# Altium schematic sheet 09_ATK_RK3588S_OSC_PLL_PMUIO.SchDoc
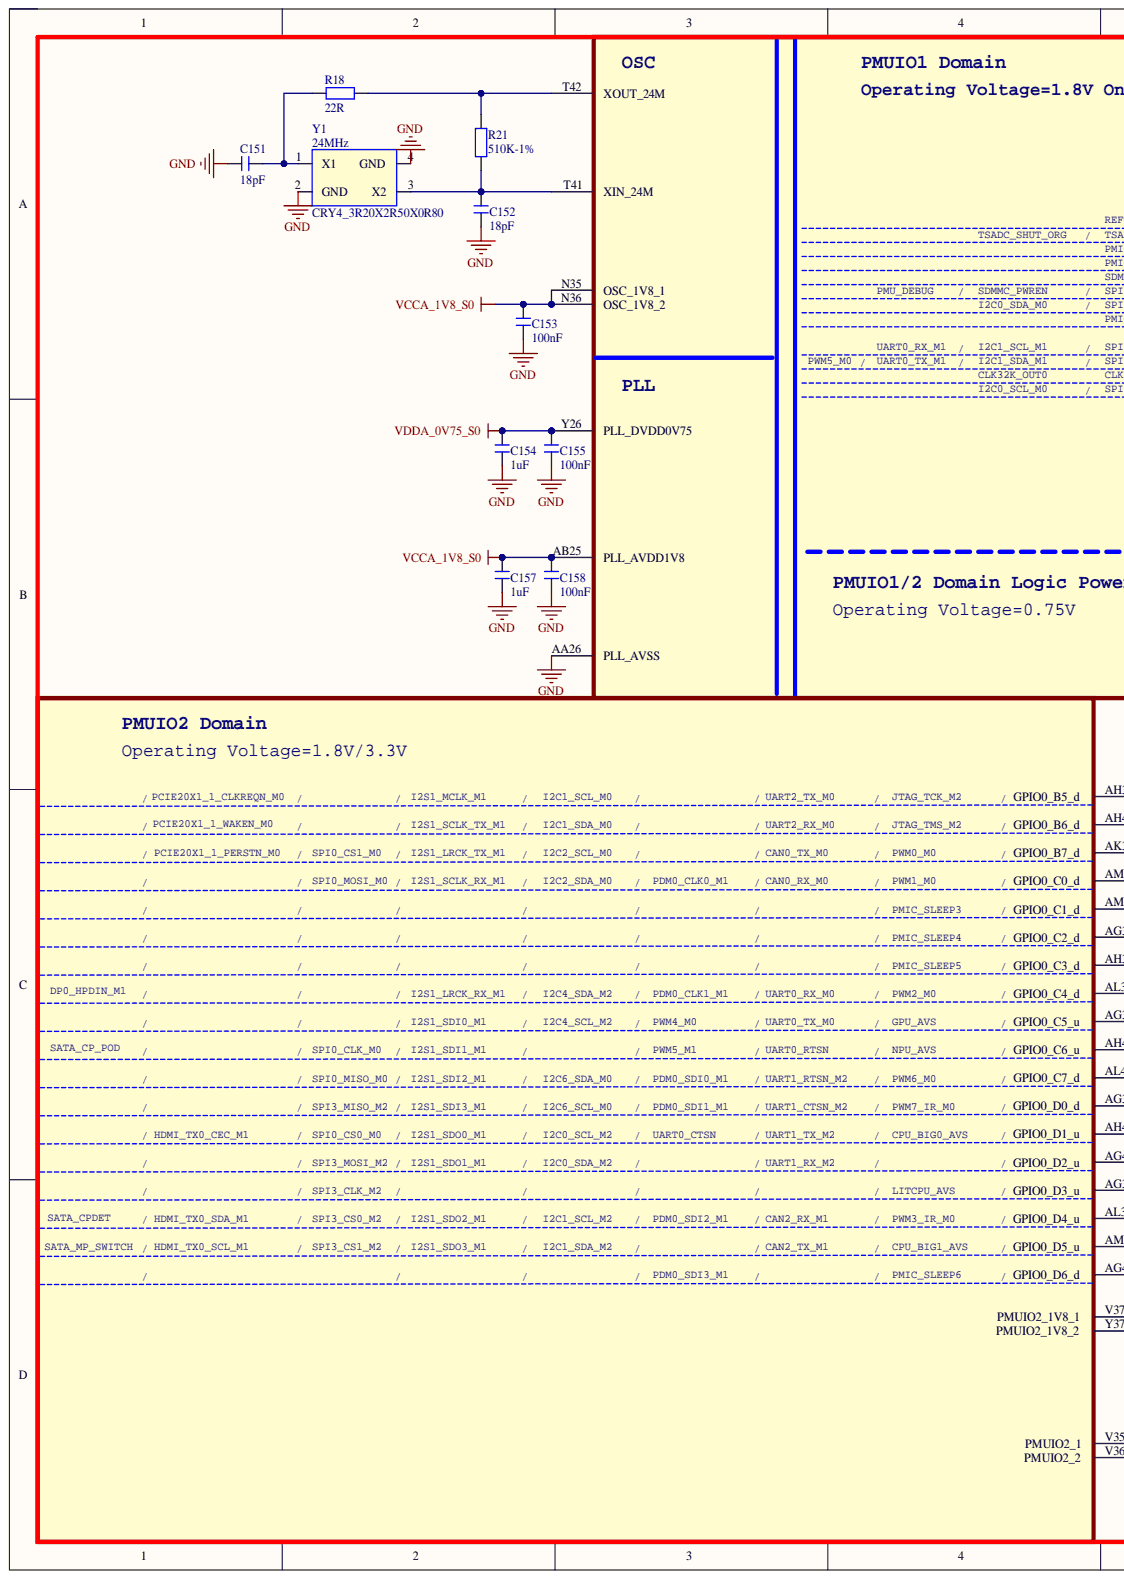
[diagram p0: 09_ATK_RK3588S_OSC_PLL_PMUIO.SchDoc, left]
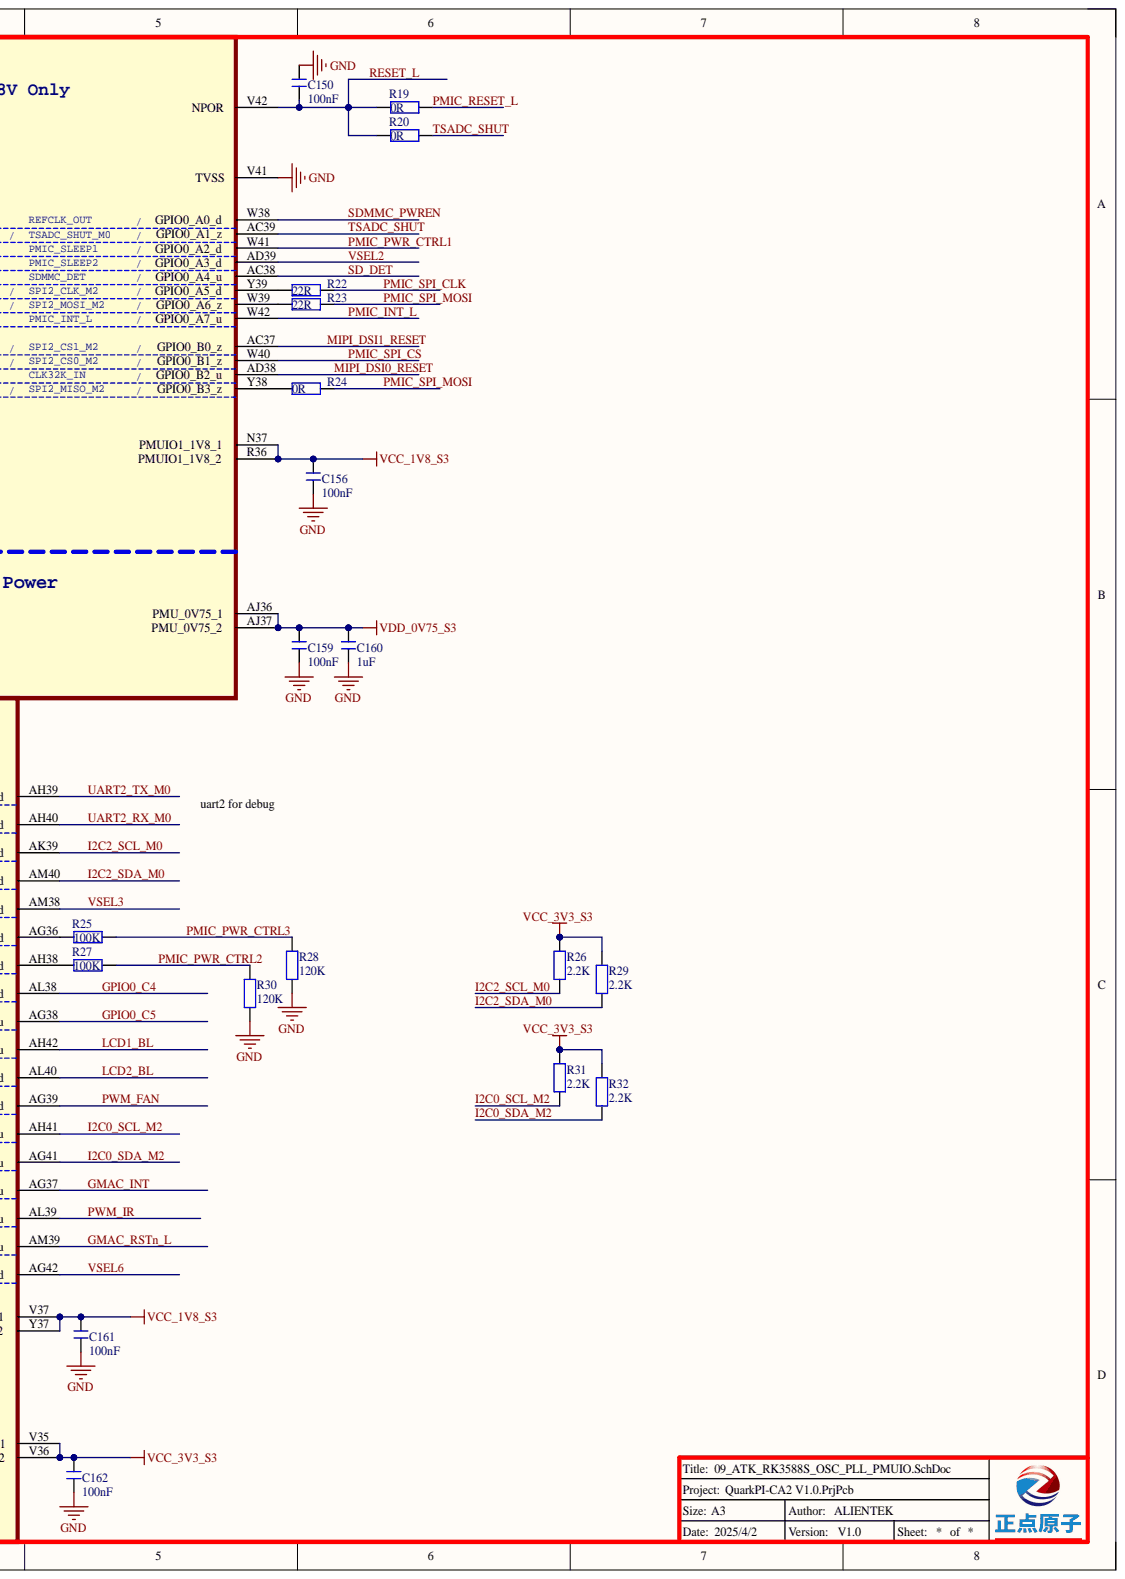
[diagram p1: 09_ATK_RK3588S_OSC_PLL_PMUIO.SchDoc, right]

## Parts
C150  100nF  [C 0201]
C151  18pF  [C 0402]
C152  18pF  [C 0402]
C153  100nF  [C 0201]
C154  1uF  [C 0201]
C155  100nF  [C 0201]
C156  100nF  [C 0201]
C157  1uF  [C 0201]
C158  100nF  [C 0201]
C159  100nF  [C 0201]
C160  1uF  [C 0201]
C161  100nF  [C 0201]
C162  100nF  [C 0201]
R18  22R  [R 0402]
R19  0R  [R 0201]
R20  0R  [R 0201]
R21  510K-1%  [R 0402]
R22  22R  [R 0201]
R23  22R  [R 0201]
R24  0R  [R 0201]
R25  100K  [R 0402]
R26  2.2K  [R 0402]
R27  100K  [R 0402]
R28  120K  [R 0402]
R29  2.2K  [R 0402]
R30  120K  [R 0402]
R31  2.2K  [R 0402]
R32  2.2K  [R 0402]
U1  RK3588S  [BGA1253_65RX65RX45R_S]  (value not drawn)  (parts E,F)
Y1  24MHz  PCB Footprint: CRY4_3R20X2R50X0R80  [XTAL-3225]

## Nets
GND: C150.1 C151.1 C152.1 C153.1 C154.1 C155.1 C156.1 C157.2 C158.2 C159.1 C160.1 C161.1 C162.1 R28.1 R30.1 U1.AA26(PLL_AVSS) U1.V41(TVSS) Y1.2(GND) Y1.4(GND)
VCC_1V8_S3: C156.2 C161.2 U1.N37(PMUIO1_1V8_1) U1.R36(PMUIO1_1V8_2) U1.V37(PMUIO2_1V8_1) U1.Y37(PMUIO2_1V8_2)
VCC_3V3_S3: C162.2 R26.2 R29.2 R31.2 R32.2 U1.V35(PMUIO2_1) U1.V36(PMUIO2_2)
VCCA_1V8_S0: C153.2 C157.1 C158.1 U1.AB25(PLL_AVDD1V8) U1.N35(OSC_1V8_1) U1.N36(OSC_1V8_2)
VDD_0V75_S3: C159.2 C160.2 U1.AJ36(PMU_0V75_1) U1.AJ37(PMU_0V75_2)
VDDA_0V75_S0: C154.2 C155.2 U1.Y26(PLL_DVDD0V75)
GMAC_INT: U1.AG37(GPIO0_D3_u)
GMAC_RSTn_L: U1.AM39(GPIO0_D5_u)
GPIO0_C4: U1.AL38(GPIO0_C4_d)
GPIO0_C5: U1.AG38(GPIO0_C5_u)
I2C0_SCL_M2: R31.1 U1.AH41(GPIO0_D1_u)
I2C0_SDA_M2: R32.1 U1.AG41(GPIO0_D2_u)
I2C2_SCL_M0: R26.1 U1.AK39(GPIO0_B7_d)
I2C2_SDA_M0: R29.1 U1.AM40(GPIO0_C0_d)
LCD1_BL: U1.AH42(GPIO0_C6_u)
LCD2_BL: U1.AL40(GPIO0_C7_d)
MIPI_DSI0_RESET: U1.AD38(GPIO0_B2_u)
MIPI_DSI1_RESET: U1.AC37(GPIO0_B0_z)
PMIC_INT_L: U1.W42(GPIO0_A7_u)
PMIC_PWR_CTRL1: U1.W41(GPIO0_A2_d)
PMIC_PWR_CTRL2: R27.2 R30.2
PMIC_PWR_CTRL3: R25.2 R28.2
PMIC_RESET_L: R19.2
PMIC_SPI_CLK: R22.2
PMIC_SPI_CS: U1.W40(GPIO0_B1_z)
PMIC_SPI_MOSI: R23.2 R24.2
PWM_FAN: U1.AG39(GPIO0_D0_d)
PWM_IR: U1.AL39(GPIO0_D4_u)
RESET_L: C150.2 R19.1 R20.1 U1.V42(NPOR)
SD_DET: U1.AC38(GPIO0_A4_u)
SDMMC_PWREN: U1.W38(GPIO0_A0_d)
TSADC_SHUT: R20.2 U1.AC39(GPIO0_A1_z)
UART2_RX_M0: U1.AH40(GPIO0_B6_d)
UART2_TX_M0: U1.AH39(GPIO0_B5_d)
VSEL2: U1.AD39(GPIO0_A3_d)
VSEL3: U1.AM38(GPIO0_C1_d)
VSEL6: U1.AG42(GPIO0_D6_d)
NetC151_2: C151.2 R18.1 Y1.1(X1)
NetC152_2: C152.2 R21.1 U1.T41(XIN_24M) Y1.3(X2)
NetR18_2: R18.2 R21.2 U1.T42(XOUT_24M)
NetR22_1: R22.1 U1.Y39(GPIO0_A5_d)
NetR23_1: R23.1 U1.W39(GPIO0_A6_z)
NetR24_1: R24.1 U1.Y38(GPIO0_B3_z)
NetR25_1: R25.1 U1.AG36(GPIO0_C2_d)
NetR27_1: R27.1 U1.AH38(GPIO0_C3_d)

## Library data of the parts
C150-C162 = C: 无极性电容; BOM名称: 无极性贴片电容; 版权归属: 正点原子; Component Kind: Standard; Library Name: 正点原子封装库V1.0.IntLib; 学习资源: www.openedv.com
R18-R32 = R: 电阻; BOM名称: 贴片电阻; 版权归属: 正点原子; Component Kind: Standard; Library Name: 正点原子封装库V1.0.IntLib; Parameter: 电阻; 学习资源: www.openedv.com
U1 = RK3588S: SPLIT_INST: TRUE; SWAP_INFO: (S1+S2+S3+S4+S5+S6+S7+S8+S9+S10+S11+S12+S13+S14+S15+S16+S17+S18+S19+S20+S21+S22+S23+S24+S25+S26); Component Kind: Standard; Library Name: atompi-ca2.SchLib
Y1 = CRYSTAL_4P: 无源晶振，24M，3225,±10ppm，8pF，Hosonic; Manufacturer: Hosonic(鸿星电子); Manufacturer PN: E3SB24.0000F08E11; Priority: A; Component Kind: Standard; Library Name: ATK-CLRK3588B.IntLib; Part Type: CRYSTAL; RK PN: E3SB24.0000F08E11; Created by: JJJ
